# Altium schematic sheet SCM20260N-Power-Special-Pins.SchDoc(SCM20260N-Power-Special-Pins)
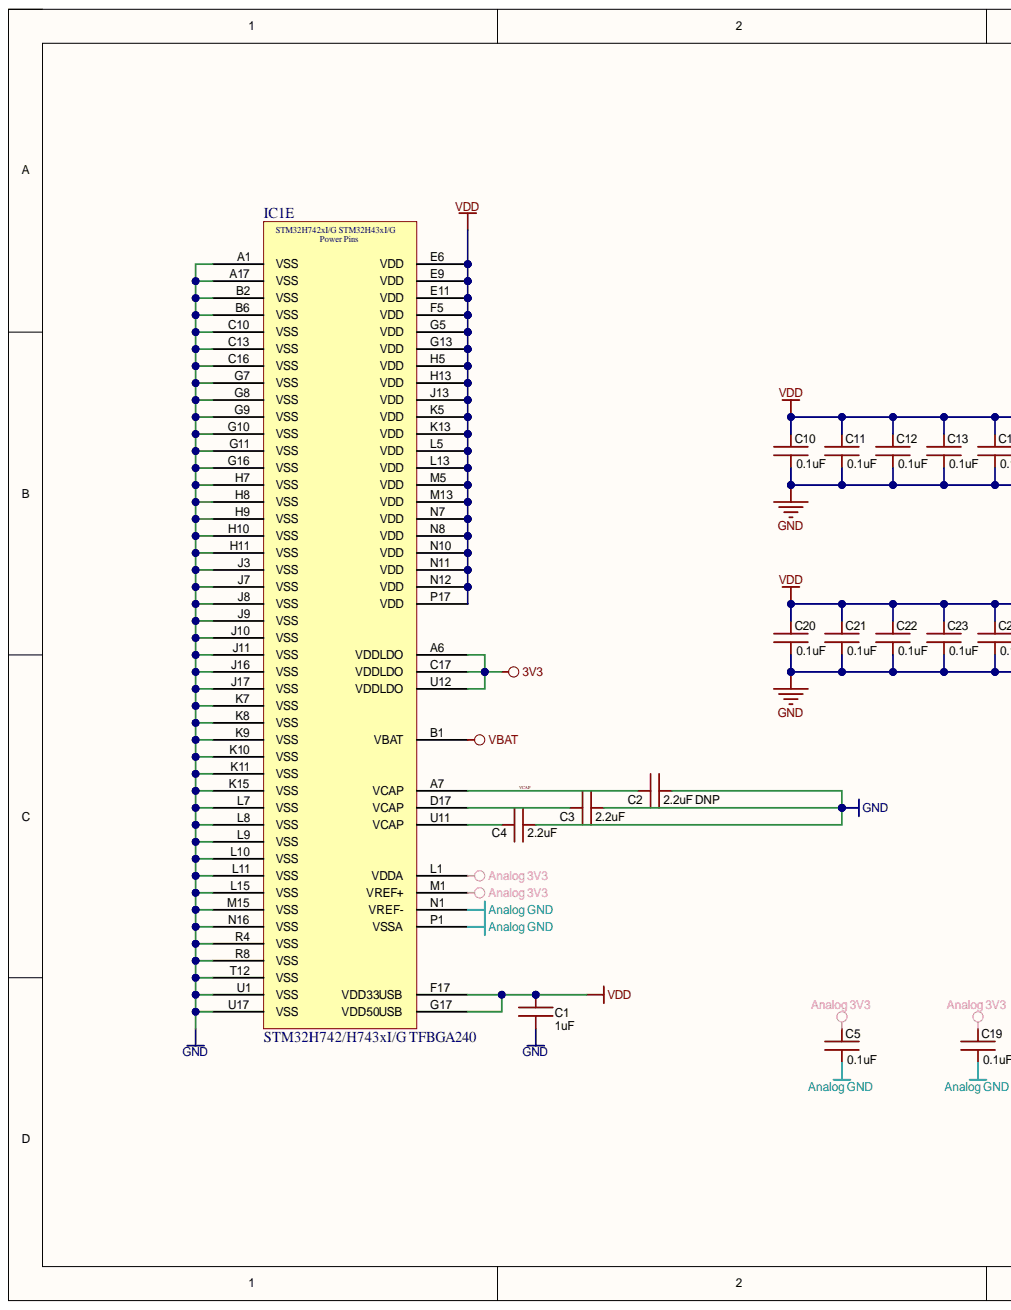
[diagram p0: SCM20260N-Power-Special-Pins.SchDoc, left]
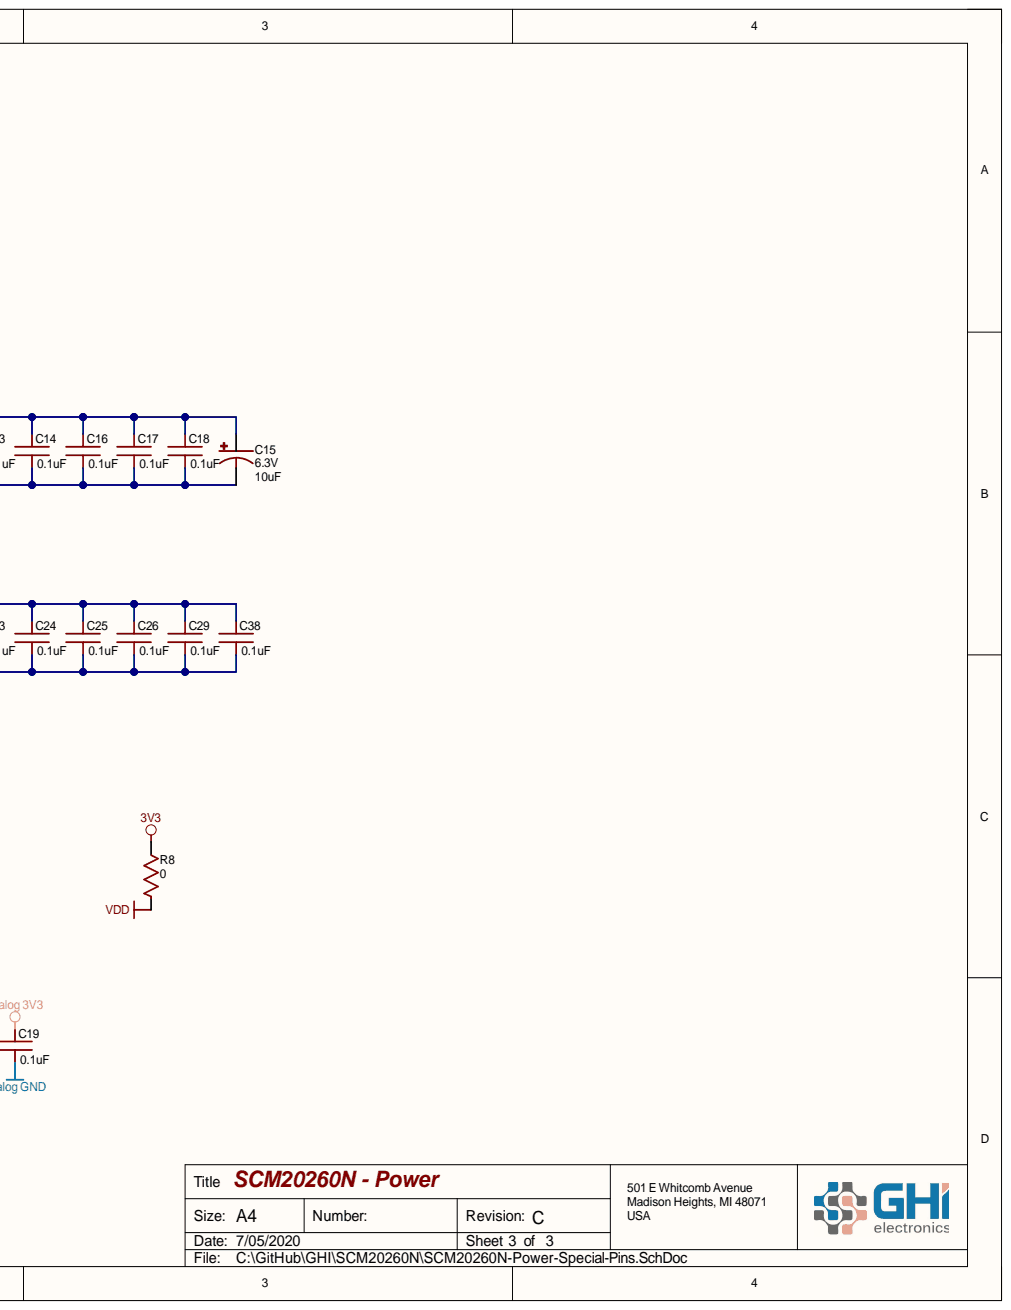
[diagram p1: SCM20260N-Power-Special-Pins.SchDoc, right]

## Parts
C1  value 1uF  Capacitance: 1uF  [C0603 (1608 Metric)]  (hidden comment: 0603 Cap 1uF)
C2  value 2.2uF DNP  Capacitance: 2.2uF DNP  [C0603 (1608 Metric)]  (hidden comment: 0603 Cap 2.2uF)
C3  value 2.2uF  Capacitance: 2.2uF  [C0603 (1608 Metric)]  (hidden comment: 0603 Cap 2.2uF)
C4  value 2.2uF  Capacitance: 2.2uF  [C0603 (1608 Metric)]  (hidden comment: 0603 Cap 2.2uF)
C5  value 0.1uF  Capacitance: 0.1uF  [C0402]  (hidden comment: 0402 Cap 0.1uF)
C10  value 0.1uF  Capacitance: 0.1uF  [C0402]  (hidden comment: 0402 Cap 0.1uF)
C11  value 0.1uF  Capacitance: 0.1uF  [C0402]  (hidden comment: 0402 Cap 0.1uF)
C12  value 0.1uF  Capacitance: 0.1uF  [C0402]  (hidden comment: 0402 Cap 0.1uF)
C13  value 0.1uF  Capacitance: 0.1uF  [C0402]  (hidden comment: 0402 Cap 0.1uF)
C14  value 0.1uF  Capacitance: 0.1uF  [C0402]  (hidden comment: 0402 Cap 0.1uF)
C15  value 10uF  Capacitance: 10uF  Voltage: 6.3V  [CASE-R 0805 (2012)]  (hidden comment: CASE-R 0805 (2012) Tant 10uF)
C16  value 0.1uF  Capacitance: 0.1uF  [C0402]  (hidden comment: 0402 Cap 0.1uF)
C17  value 0.1uF  Capacitance: 0.1uF  [C0402]  (hidden comment: 0402 Cap 0.1uF)
C18  value 0.1uF  Capacitance: 0.1uF  [C0402]  (hidden comment: 0402 Cap 0.1uF)
C19  value 0.1uF  Capacitance: 0.1uF  [C0402]  (hidden comment: 0402 Cap 0.1uF)
C20  value 0.1uF  Capacitance: 0.1uF  [C0402]  (hidden comment: 0402 Cap 0.1uF)
C21  value 0.1uF  Capacitance: 0.1uF  [C0402]  (hidden comment: 0402 Cap 0.1uF)
C22  value 0.1uF  Capacitance: 0.1uF  [C0402]  (hidden comment: 0402 Cap 0.1uF)
C23  value 0.1uF  Capacitance: 0.1uF  [C0402]  (hidden comment: 0402 Cap 0.1uF)
C24  value 0.1uF  Capacitance: 0.1uF  [C0402]  (hidden comment: 0402 Cap 0.1uF)
C25  value 0.1uF  Capacitance: 0.1uF  [C0402]  (hidden comment: 0402 Cap 0.1uF)
C26  value 0.1uF  Capacitance: 0.1uF  [C0402]  (hidden comment: 0402 Cap 0.1uF)
C29  value 0.1uF  Capacitance: 0.1uF  [C0402]  (hidden comment: 0402 Cap 0.1uF)
C38  value 0.1uF  Capacitance: 0.1uF  [C0402]  (hidden comment: 0402 Cap 0.1uF)
IC1  STM32H742/H743xI/G TFBGA240  [TFBGA240+25]  (part E)
R8  value 0  [R0603 (1608 Metric)]  (hidden comment: 0603 Res 0)

## Nets
3V3: IC1.A6(VDDLDO) IC1.C17(VDDLDO) IC1.U12(VDDLDO) R8.2
Analog 3V3: C5.2 C19.2 IC1.L1(VDDA) IC1.M1(VREF+)
Analog GND: C5.1 C19.1 IC1.N1(VREF-) IC1.P1(VSSA)
GND: C1.1 C2.2 C3.2 C4.2 C10.1 C11.1 C12.1 C13.1 C14.1 C15.2 C16.1 C17.1 C18.1 C20.1 C21.1 C22.1 C23.1 C24.1 C25.1 C26.1 C29.1 C38.1 IC1.A1(VSS) IC1.A17(VSS) IC1.B2(VSS) IC1.B6(VSS) IC1.C10(VSS) IC1.C13(VSS) IC1.C16(VSS) IC1.G7(VSS) IC1.G8(VSS) IC1.G9(VSS) IC1.G10(VSS) IC1.G11(VSS) IC1.G16(VSS) IC1.H7(VSS) IC1.H8(VSS) IC1.H9(VSS) IC1.H10(VSS) IC1.H11(VSS) IC1.J3(VSS) IC1.J7(VSS) IC1.J8(VSS) IC1.J9(VSS) IC1.J10(VSS) IC1.J11(VSS) IC1.J16(VSS) IC1.J17(VSS) IC1.K7(VSS) IC1.K8(VSS) IC1.K9(VSS) IC1.K10(VSS) IC1.K11(VSS) IC1.K15(VSS) IC1.L7(VSS) IC1.L8(VSS) IC1.L9(VSS) IC1.L10(VSS) IC1.L11(VSS) IC1.L15(VSS) IC1.M15(VSS) IC1.N16(VSS) IC1.R4(VSS) IC1.R8(VSS) IC1.T12(VSS) IC1.U1(VSS) IC1.U17(VSS)
VBAT: IC1.B1(VBAT)
VDD: C1.2 C10.2 C11.2 C12.2 C13.2 C14.2 C15.1 C16.2 C17.2 C18.2 C20.2 C21.2 C22.2 C23.2 C24.2 C25.2 C26.2 C29.2 C38.2 IC1.E6(VDD) IC1.E9(VDD) IC1.E11(VDD) IC1.F5(VDD) IC1.F17(VDD33USB) IC1.G5(VDD) IC1.G13(VDD) IC1.G17(VDD50USB) IC1.H5(VDD) IC1.H13(VDD) IC1.J13(VDD) IC1.K5(VDD) IC1.K13(VDD) IC1.L5(VDD) IC1.L13(VDD) IC1.M5(VDD) IC1.M13(VDD) IC1.N7(VDD) IC1.N8(VDD) IC1.N10(VDD) IC1.N11(VDD) IC1.N12(VDD) IC1.P17(VDD) R8.1
VCAP: C2.1 IC1.A7(VCAP)
NetC3_1: C3.1 IC1.D17(VCAP)
NetC4_1: C4.1 IC1.U11(VCAP)

## Library data of the parts
C1 = 0603 Cap 1uF: 2.2uF ±10% 10V X5R 0603 Multilayer Ceramic Capacitors MLCC - SMD/SMT RoHS; Manufacturer: YAGEO; Mfr.Part #: CC0603KRX5R7BB105; Voltage: 16V; Category: Ceramic; Component Kind: Standard; LCSC Part #: C106215; Package: 0603; Tolerance: ±10%; Library Name: GHI-Passives.SchLib
C2-C4 = 0603 Cap 2.2uF: 2.2uF ±10% 10V X5R 0603 Multilayer Ceramic Capacitors MLCC - SMD/SMT RoHS; Manufacturer: YAGEO; Mfr.Part #: CC0603KRX5R6BB225; Category: Ceramic; Component Kind: Standard; LCSC Part #: C106213; Package: 0603; Tolerance: ±10%; Voltage: 10V; Library Name: GHI-Passives.SchLib
C5 C10-C14 C16-C26 C29 C38 = 0402 Cap 0.1uF: 0.1uF ±10% 16V X7R 0402 Multilayer Ceramic Capacitors MLCC - SMD/SMT RoHS; Mfr.Part #: CC0402KRX7R7BB104; Category: Ceramic; Component Kind: Standard; LCSC Part #: C60474; Package: 0402; Tolerance: ±10%; Voltage: 16V; Library Name: GHI-Passives.SchLib
C15 = CASE-R 0805 (2012) Tant 10uF: 10uF ±10% 6.3V 6 Ω @ 100kHz -55℃ ~ +125℃ CASE-R_2012 Tantalum Capacitors RoHS; Manufacturer: AVX; Mfr.Part #: TAJR106K006RNJ; Category: Tantalum; Component Kind: Standard; Digikey: 478-3273-2-ND; LCSC Part #: C132274; Package: CASE-N_2012; Tolerance: ±10%; Library Name: GHI-Passives.SchLib
IC1 = STM32H742/H743xI/G TFBGA240+25: STM32H742/H743xI/G TFBGA240+25 (14 x 14mm); Component Kind: Standard; Library Name: GHI Processors.SchLib
R8 = 0603 Res 0: ±5% 1/10W 0603 Chip Resistor - Surface Mount RoHS; Manufacturer: YAGEO; Mfr.Part #: RC0603JR-070RL; Voltage Rating: *V; Component Kind: Standard; LCSC Part #: C95177; Package: 0603; Power Rating: 1/10W; Resistance: 0; Resistance Tolerance: ±5%; Library Name: GHI-Passives.SchLib
